# Altium schematic sheet 10-USB-Prog.SchDoc(10-USB-Prog)
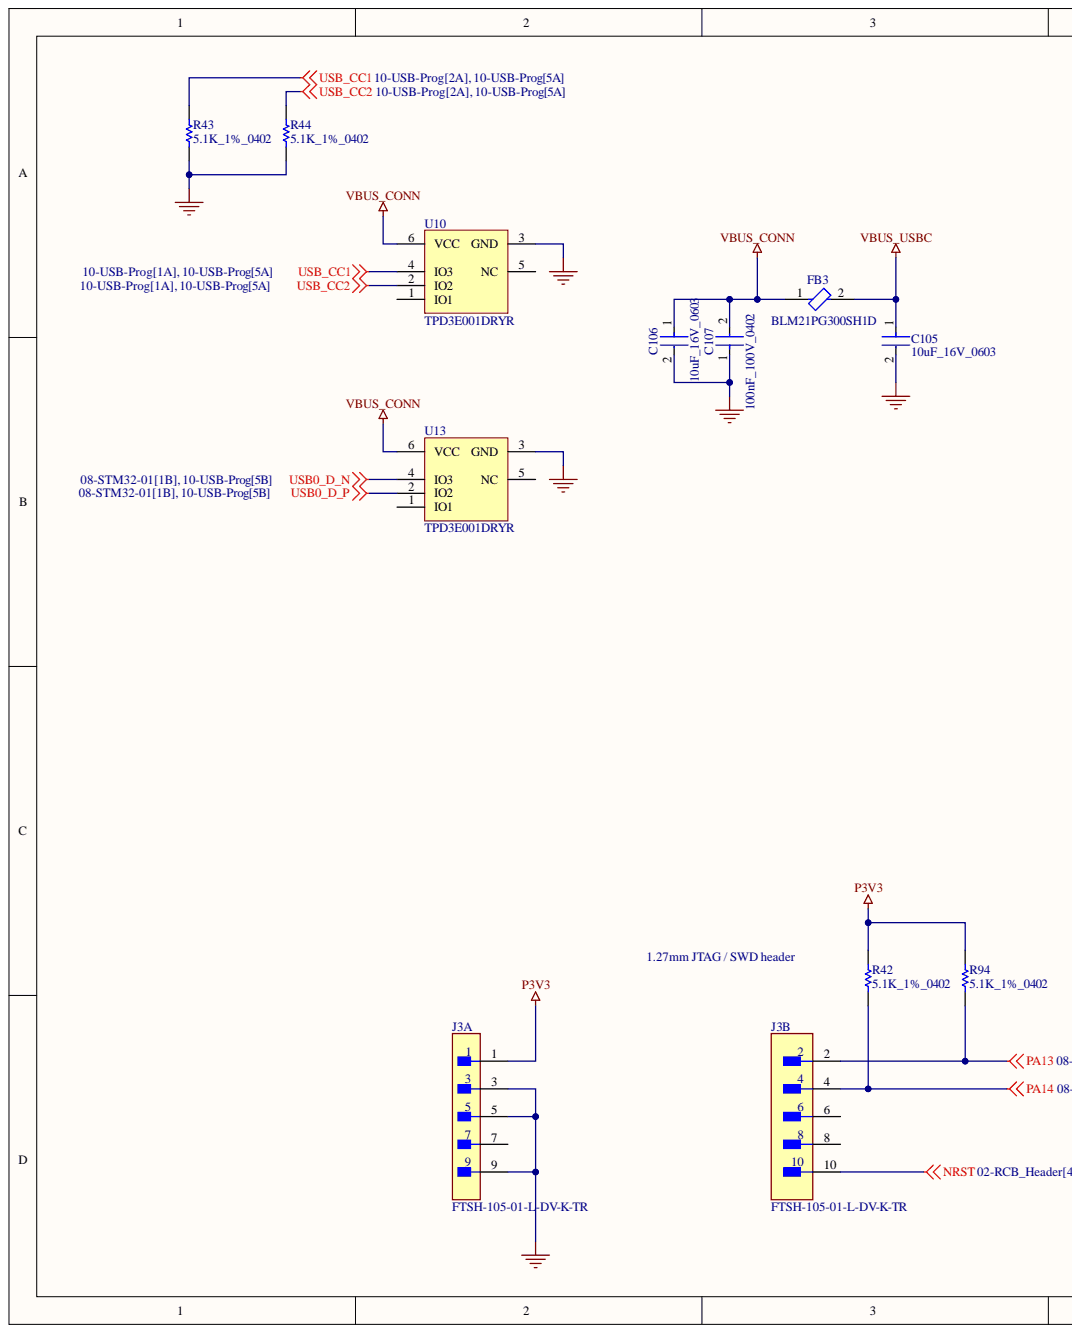
[diagram p0: 10-USB-Prog.SchDoc, left]
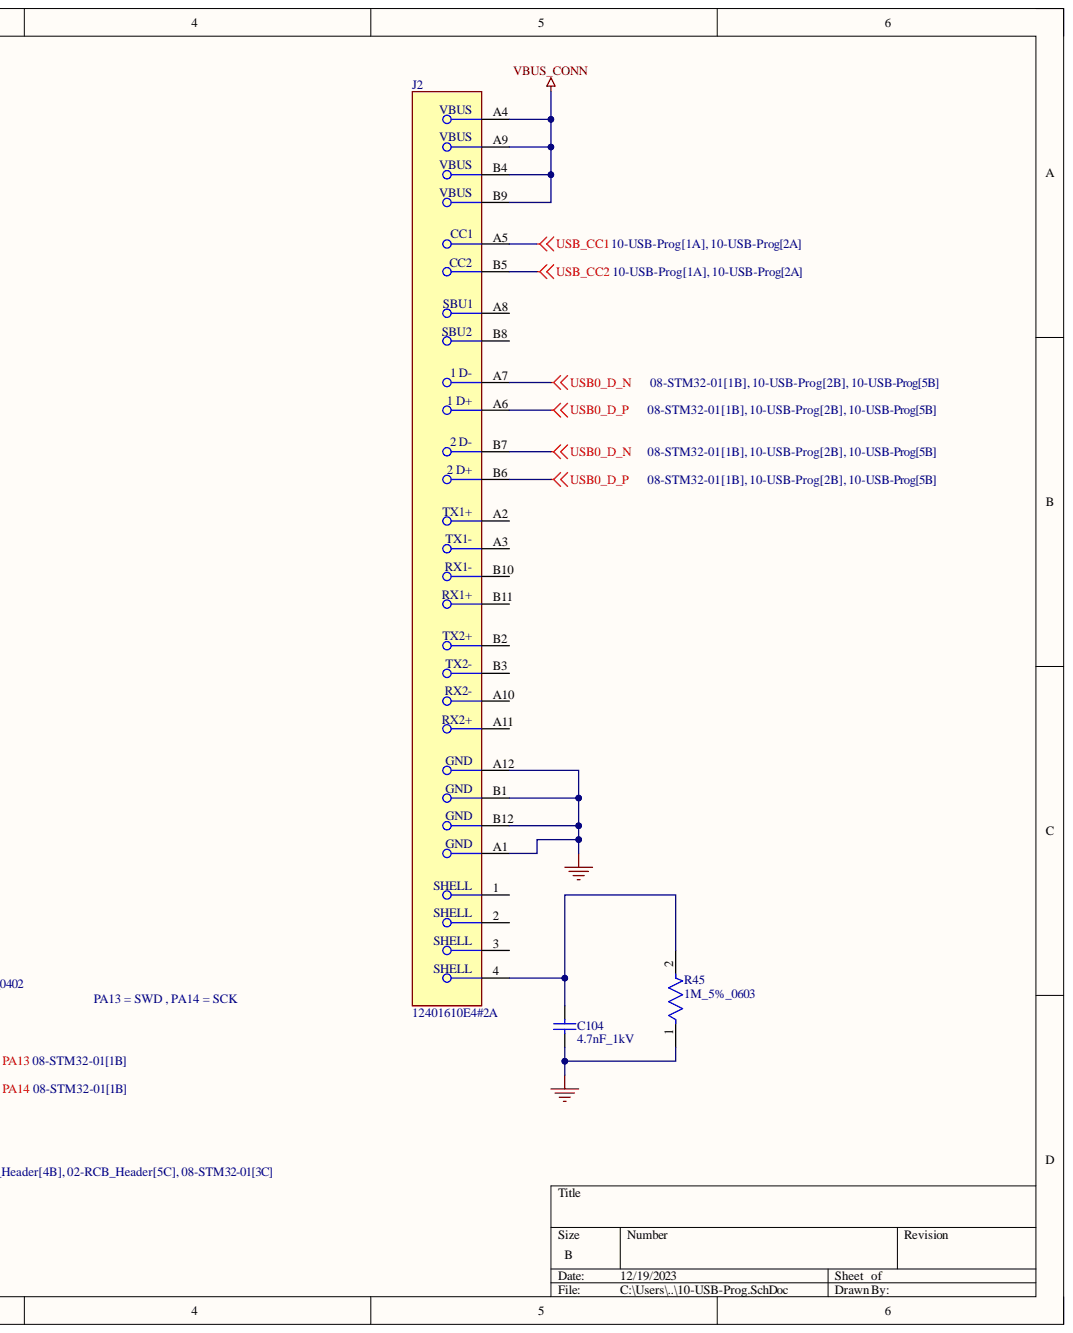
[diagram p1: 10-USB-Prog.SchDoc, right]

## Parts
C104  4.7nF_1kV  [CAPC2013X145X50ML20T25]
C105  10uF_16V_0603  [FP-0603-L_1_6_0_2-W_0_8_0-MFG]
C106  10uF_16V_0603  [FP-0603-L_1_6_0_2-W_0_8_0-MFG]
C107  100nF_100V_0402  [FP-GRM155-0_05-IPC_C]
FB3  BLM21PG300SH1D  [FP-BLM21-0_2-t0_85-e0_5-d-IPC_B]
J2  12401610E4#2A  [FP-12401610E4#2A-MFG]
J3  FTSH-105-01-L-DV-K-TR  [FP-FTSH-105-01-L-DV-K-TR-MFG]  (parts A,B)
R42  5.1K_1%_0402  [RESC1005X40X25ML10T10]
R43  5.1K_1%_0402  [RESC1005X40X25ML10T10]
R44  5.1K_1%_0402  [RESC1005X40X25ML10T10]
R45  1M_5%_0603  [FP-RC1608-MFG]
R94  5.1K_1%_0402  [RESC1005X40X25ML10T10]
U10  TPD3E001DRYR  [DRY0006A]
U13  TPD3E001DRYR  [DRY0006A]

## Nets
GND: C104.1 C105.2 C106.2 C107.1 J2.A1 J2.A12 J2.B1 J2.B12 J3.3 J3.5 J3.9 R43.1 R44.1 R45.1 U10.3(GND) U13.3(GND)
NRST: J3.10
P3V3: J3.1 R42.2 R94.2
PA13: J3.2 R94.1
PA14: J3.4 R42.1
USB0_D_N: J2.A7 J2.B7 U13.4(IO3)
USB0_D_P: J2.A6 J2.B6 U13.2(IO2)
USB_CC1: J2.A5 R43.2 U10.4(IO3)
USB_CC2: J2.B5 R44.2 U10.2(IO2)
VBUS_CONN: C106.1 C107.2 FB3.1 J2.A4 J2.A9 J2.B4 J2.B9 U10.6(VCC) U13.6(VCC)
VBUS_USBC: C105.1 FB3.2
NetC104_2: C104.2 J2.4 R45.2

Not connected: J2 1 2 3 A2 A3 A8 A10 A11 B2 B3 B8 B10 B11; J3 6 7 8; U10 1(IO1) 5(NC); U13 1(IO1) 5(NC)

## Library data of the parts
C104 = CMP-2007-01061-1: Manufacturer: Kemet; Part Number: C0805W472KDRACTU; Component Kind: Standard; Library Name: Altium Content Vault
C105 C106 = CMP-2000-06226-4: Multilayer Ceramic Capacitor 10uF 16V X5R 20% SMD 0603 T/R; Capacitance: 10uF; Case Code (Imperial): 0603; Case Code (Metric): 1608; Case/Package: 0603; Component Kind: Standard; Construction: Flat; Dielectric: X5R; Halogen Free: Halogen Free; Height: 0.8mm; Length: 0.063inch; Library Name: Altium Content Vault; Max Operating Temperature: 85°C; Min Operating Temperature: -55°C; Mount: Surface Mount; Package Quantity: 1; Packaging: Tape and Reel; RoHS Compliant: Yes; Series: M; Termination: SMD/SMT; Thickness: 0.031inch; Tolerance: 20%; Voltage Rating: 16V; Voltage Rating (DC): 16V; Width: 0.031inch
C107 = CMP-06035-008289-1: Chip Multilayer Ceramic Capacitors for General Purpose, 0402, 0.10uF, X5R, 15%, 10%, 100V; Capacitance: 100nF; Case Code (Imperial): 0402; Case Code (Metric): 1005; Case/Package: 0402; Component Kind: Standard; Dielectric: X5R; Height: 0.5mm; Lead Free: Lead Free; Length: 0.039inch; Library Name: Altium Content Vault; Max Operating Temperature: 85°C; Min Operating Temperature: -55°C; Mount: Surface Mount; Package Quantity: 10000; Packaging: Tape and Reel; REACH SVHC: No SVHC; Termination: SMD/SMT; Thickness: 0.022inch; Tolerance: 10%; Voltage Rating: 100V; Voltage Rating (DC): 100V; Weight: 0.000212oz; Width: 0.5mm
FB3 = CMP-06046-001820-1: Chip Ferrite Bead, 0805, 30Ω @ 100MHz, 0.014Ω, , 4A; Current Rating: 3A; DC Resistance (DCR): 14mR; DC Resistance-Max: 15MR; Impedance: 30R; Material: Steel; Number of Circuits: 1; Shielding: Unshielded; Tolerance: 25%; Case Code (Imperial): 0805; Case Code (Metric): 2012; Case/Package: 0805; Component Kind: Standard; Core Material: Ferrite; Depth: 1.45mm; Height: 0.041inch; Lead Free: Lead Free; Length: 2mm; Library Name: Altium Content Vault; Max Current Rating: 4A; Max DC Current: 3A; Max Operating Temperature: 125°C; Min Operating Temperature: -55°C; Mount: Surface Mount; Number of Lines: 1; Package Quantity: 4000; Packaging: Tape and Reel; Ratings: AEC-Q200; REACH SVHC: No SVHC; RoHS Compliant: Yes; Series: EMIFIL®, BLM21P; Termination: SMD/SMT; Test Frequency: 100MHz; Weight: 0.000194oz; Width: 1.25mm
J2 = CMP-02355-000003-1: CONN RCP USB3.1 TYPEC 24P SMD RA; Connector Type: USB; Gender: Receptacle; Number of Contacts: 24; Component Kind: Standard; Library Name: Altium Content Vault; Mount: Surface Mount; Number of Pins: 24; Packaging: Cut Tape
J3 = CMP-2000-06293-2: CONN HEADER SMD 10POS 1.27MM; Connector Type: Male Pin; Current Rating: 1.75A; Gender: Male; Number of Contacts: 10; Row Spacing: 0.05inch; Color: Black; Component Kind: Standard; Contact Material: Bronze; Contact Mating Length: 0.12inch; Contact Plating: Gold; Depth: 3.43mm; Housing Color: Black; Housing Material: Polymer; Lead Free: Lead Free; Length: 6.35mm; Library Name: Altium Content Vault; Max Current Rating: 1.75A; Max Operating Temperature: 125°C; Min Operating Temperature: -55°C; Mount: Surface Mount; Number of Positions: 10; Number of Rows: 2; Orientation: Straight; Package Quantity: 550; Packaging: Tape and Reel; Pitch: 1.27mm; Radiation Hardening: No; REACH SVHC: No SVHC; Termination: Solder
R42-R44 R94 = CMP-2002-04046-1: Manufacturer: KOA Speer; Part Number: RK73H1ETTP5101F; Component Kind: Standard; Library Name: Altium Content Vault
R45 = CMP-13261-001786-1: Res Thick Film 0603 1MΩ 5% 0.1W ±100ppm/°C Molded SMD T/R; Max Power Dissipation: 100mW; Resistance: 1MR; Voltage Rating (DC): 50V; Case Code (Imperial): 0603; Case Code (Metric): 1608; Case/Package: 0603; Component Kind: Standard; Composition: Thick Film; Contact Plating: Tin; Depth: 0.8mm; Features: Moisture Resistant; Height: 0.022inch; Lead Free: Lead Free; Length: 1.6mm; Library Name: Altium Content Vault; Max Operating Temperature: 155°C; Military Standard: Not; Min Operating Temperature: -55°C; Number of Terminations: 2; Packaging: Tape and Reel; Power Rating: 100mW; Radiation Hardening: No; Temperature Coefficient: 100ppm/°C; Tolerance: 5%; Width: 0.031inch
U10 U13 = CMP-0326-00032-3: Low-Capacitance + / - 15 kV ESD-Protection Array for High-Speed Data Interfaces, 3 Channels, -40 to +85 degC, 6-pin SON (DRY), Green (RoHS & no Sb/Br); Manufacturer: Texas Instruments; Breakdown Voltage(Min)(V): 11; IEC 61000-4-2 Air-Gap(+/- kV): 15; IEC 61000-4-2 Contact(+/- kV): 8; IO Capacitance(Typ)(pF): 1.5; IO Leakage Current(nA): Jan-00; SubFamily: ESD Solution; USB High Speed: TRUE; Component Kind: Standard; ComponentLink1Description: Manufacturer URL; ComponentLink1URL: http://www.ti.com/; ComponentLink2Description: Datasheet; ComponentLink2URL: http://www.ti.com/general/docs/lit/getliterature.tsp?genericPartNumber=TPD3E001&fileType=pdf; ComponentLink3Description: URL Link1; ComponentLink3URL: SamplesURL:http://www.ti.com/product/tpd3e001#samples; DatasheetVersion: SLLS683D; Eco-Plan: Green (RoHS & no Sb/Br); Library Name: Altium Content Vault; Mounting Technology: Surface Mount; PackageDescription: 6-Pin Plastic Small Outline No-Lead, 1 x 1.45 x 0.6 mm Body, 0.5 mm Pitch; PackageReference: DRY0006A; PackageVersion: revF, Dec-2011; PartNumber: TPD3E001DRYR
